# Altium schematic sheet [03] - 28PINS SCHEMATIC.SchDoc("[03] - 28PINS SCHEMATIC")
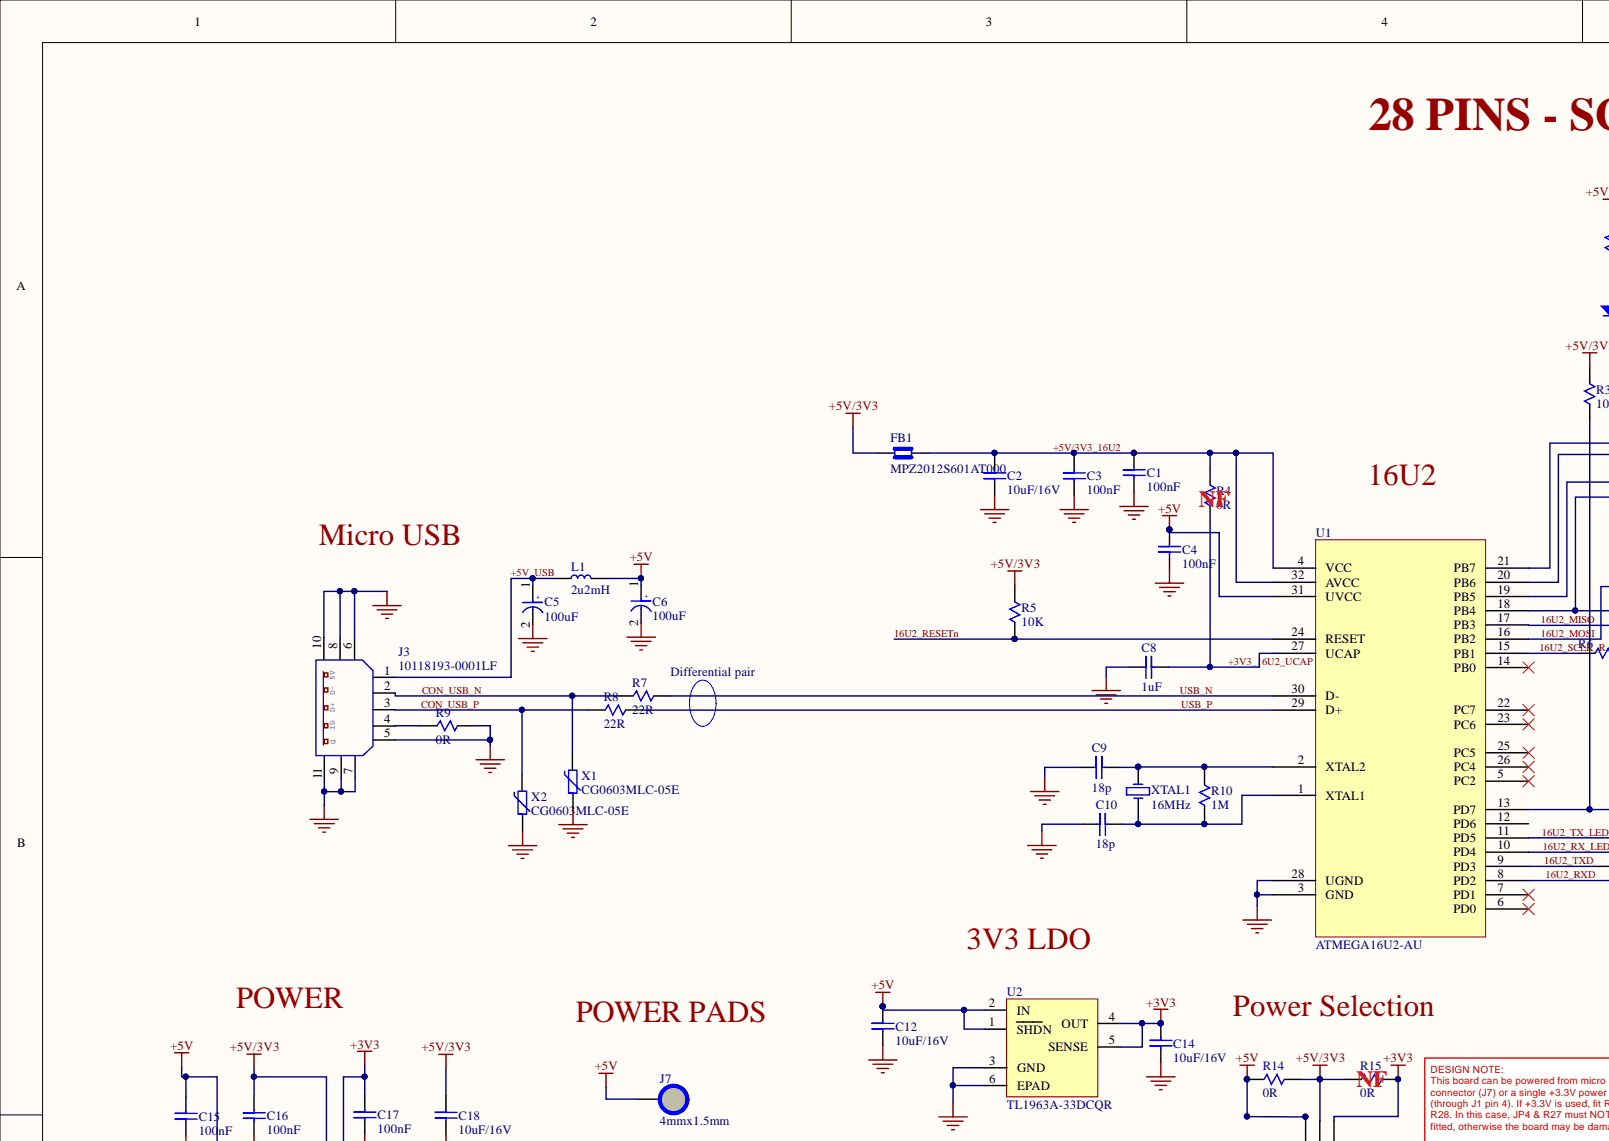
[diagram p0: [03] - 28PINS SCHEMATIC.SchDoc, top left]
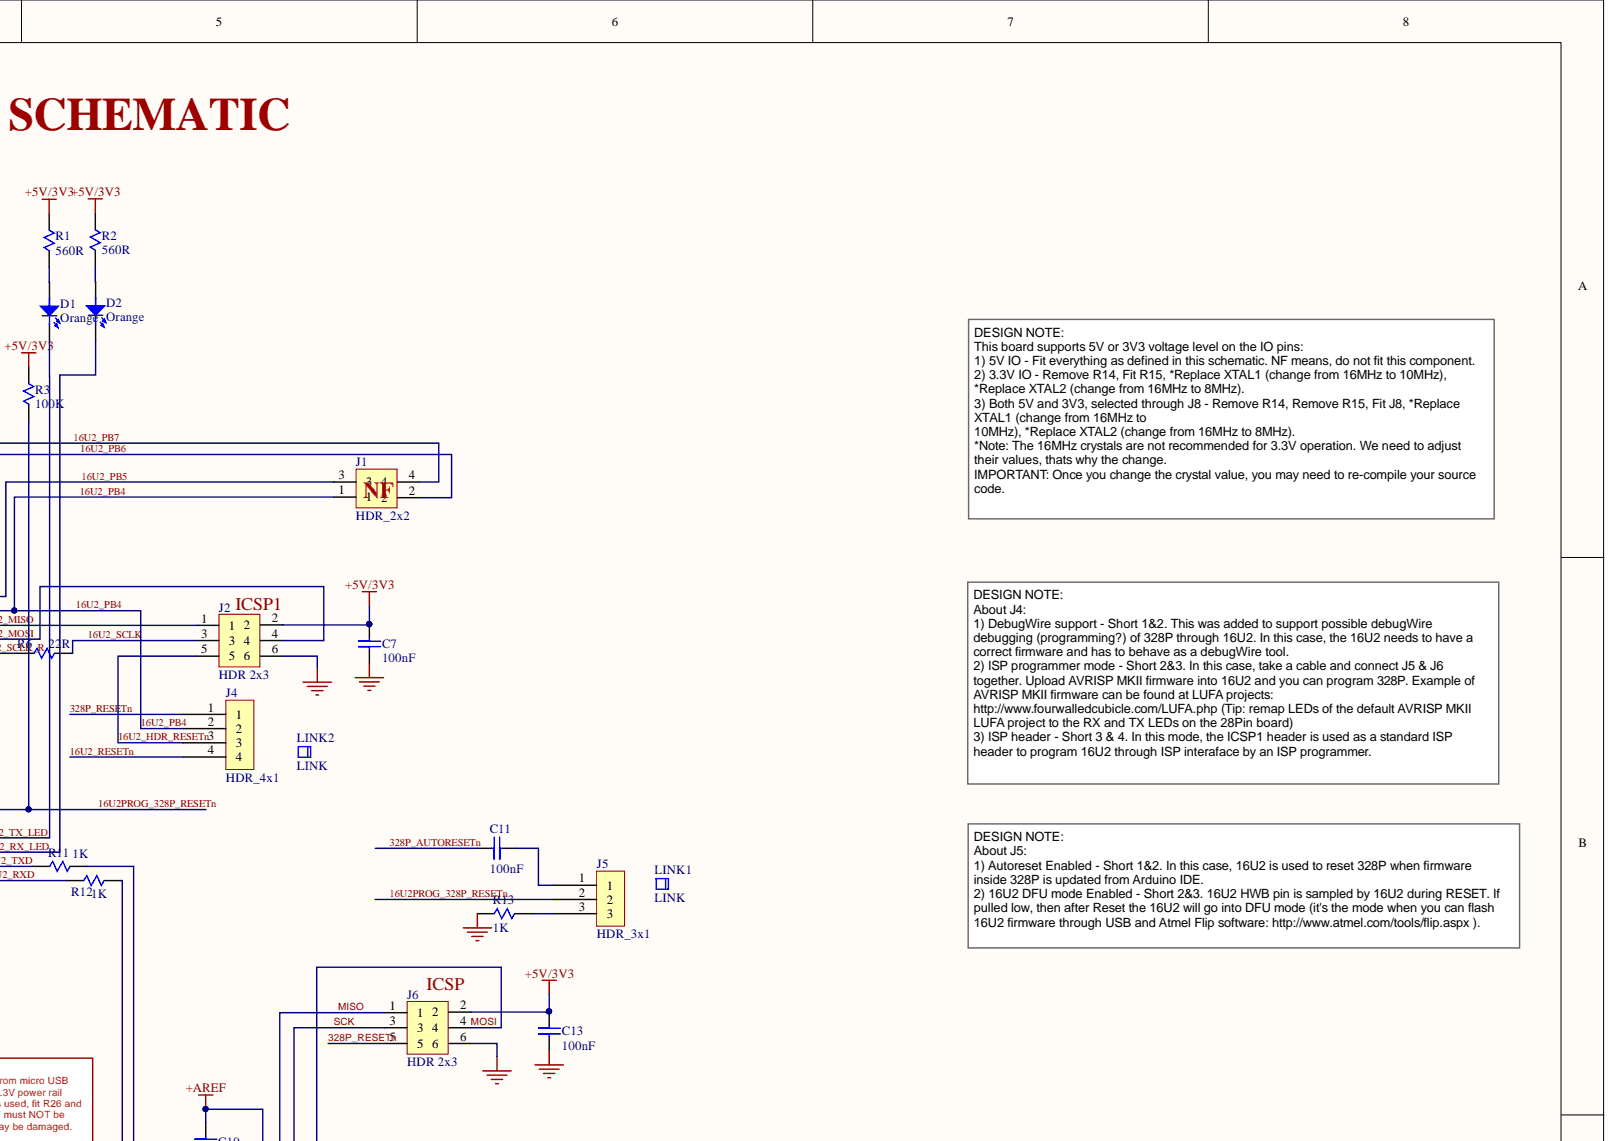
[diagram p1: [03] - 28PINS SCHEMATIC.SchDoc, top right]
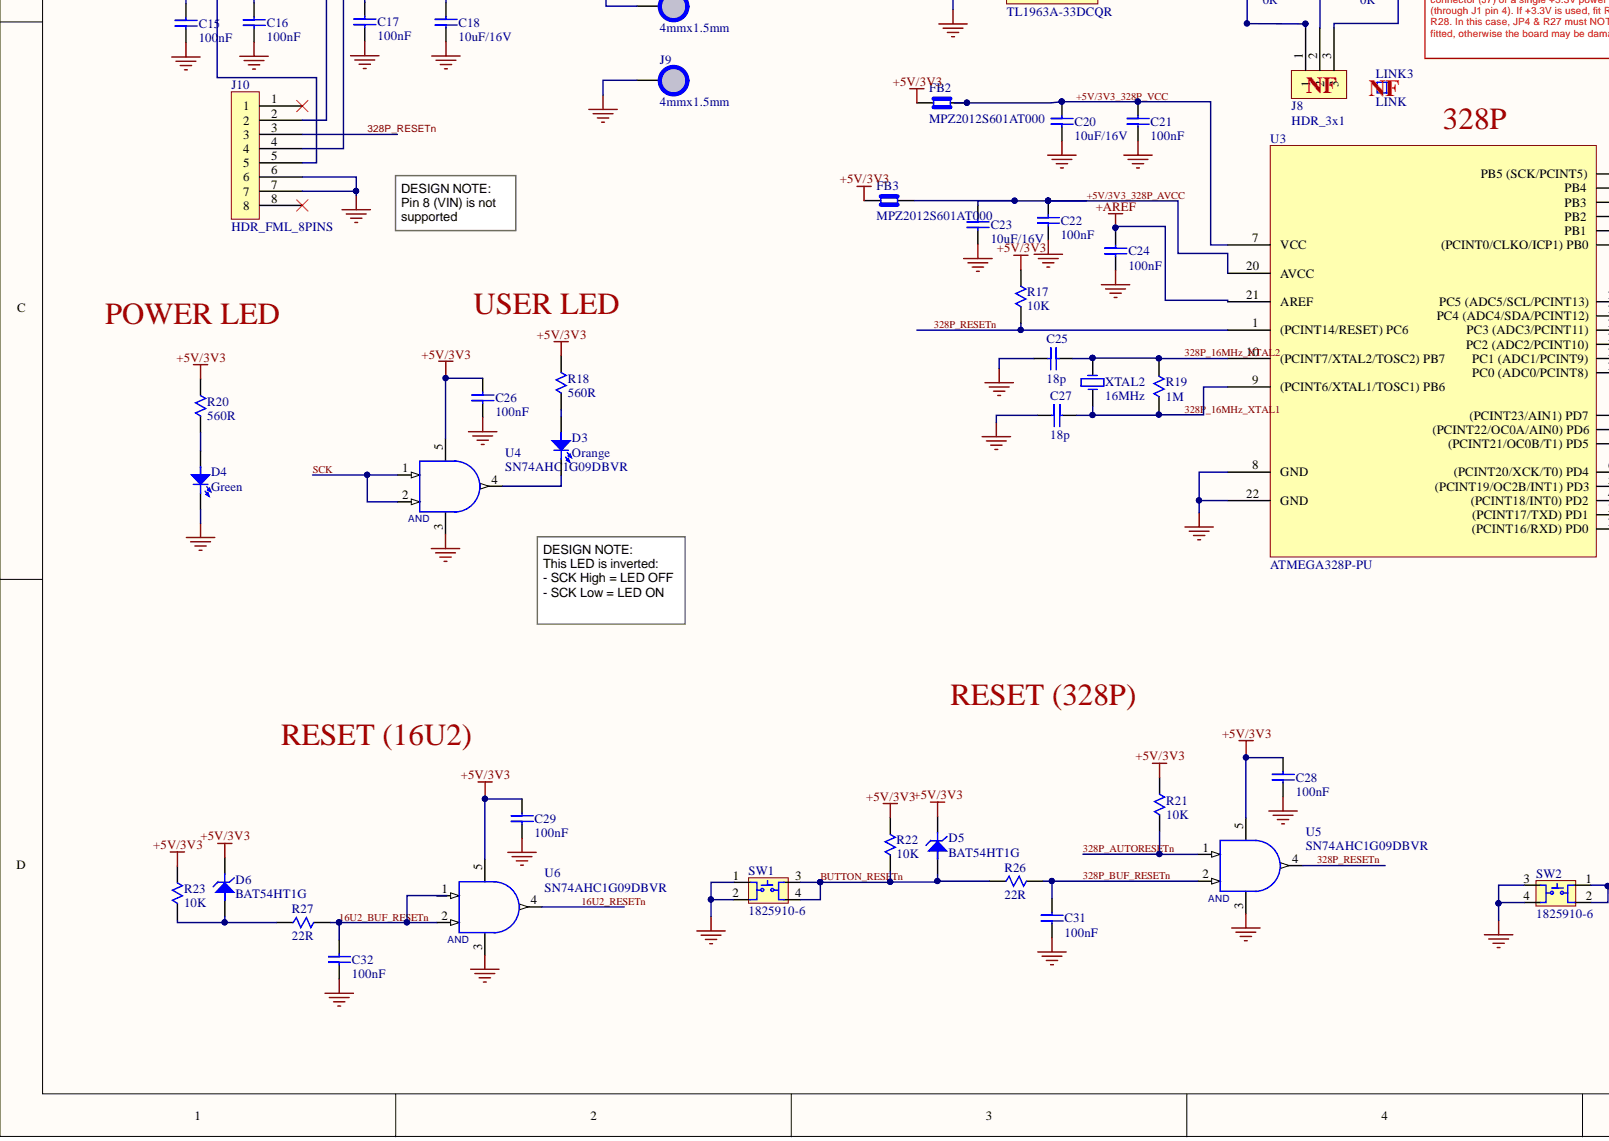
[diagram p2: [03] - 28PINS SCHEMATIC.SchDoc, bottom left]
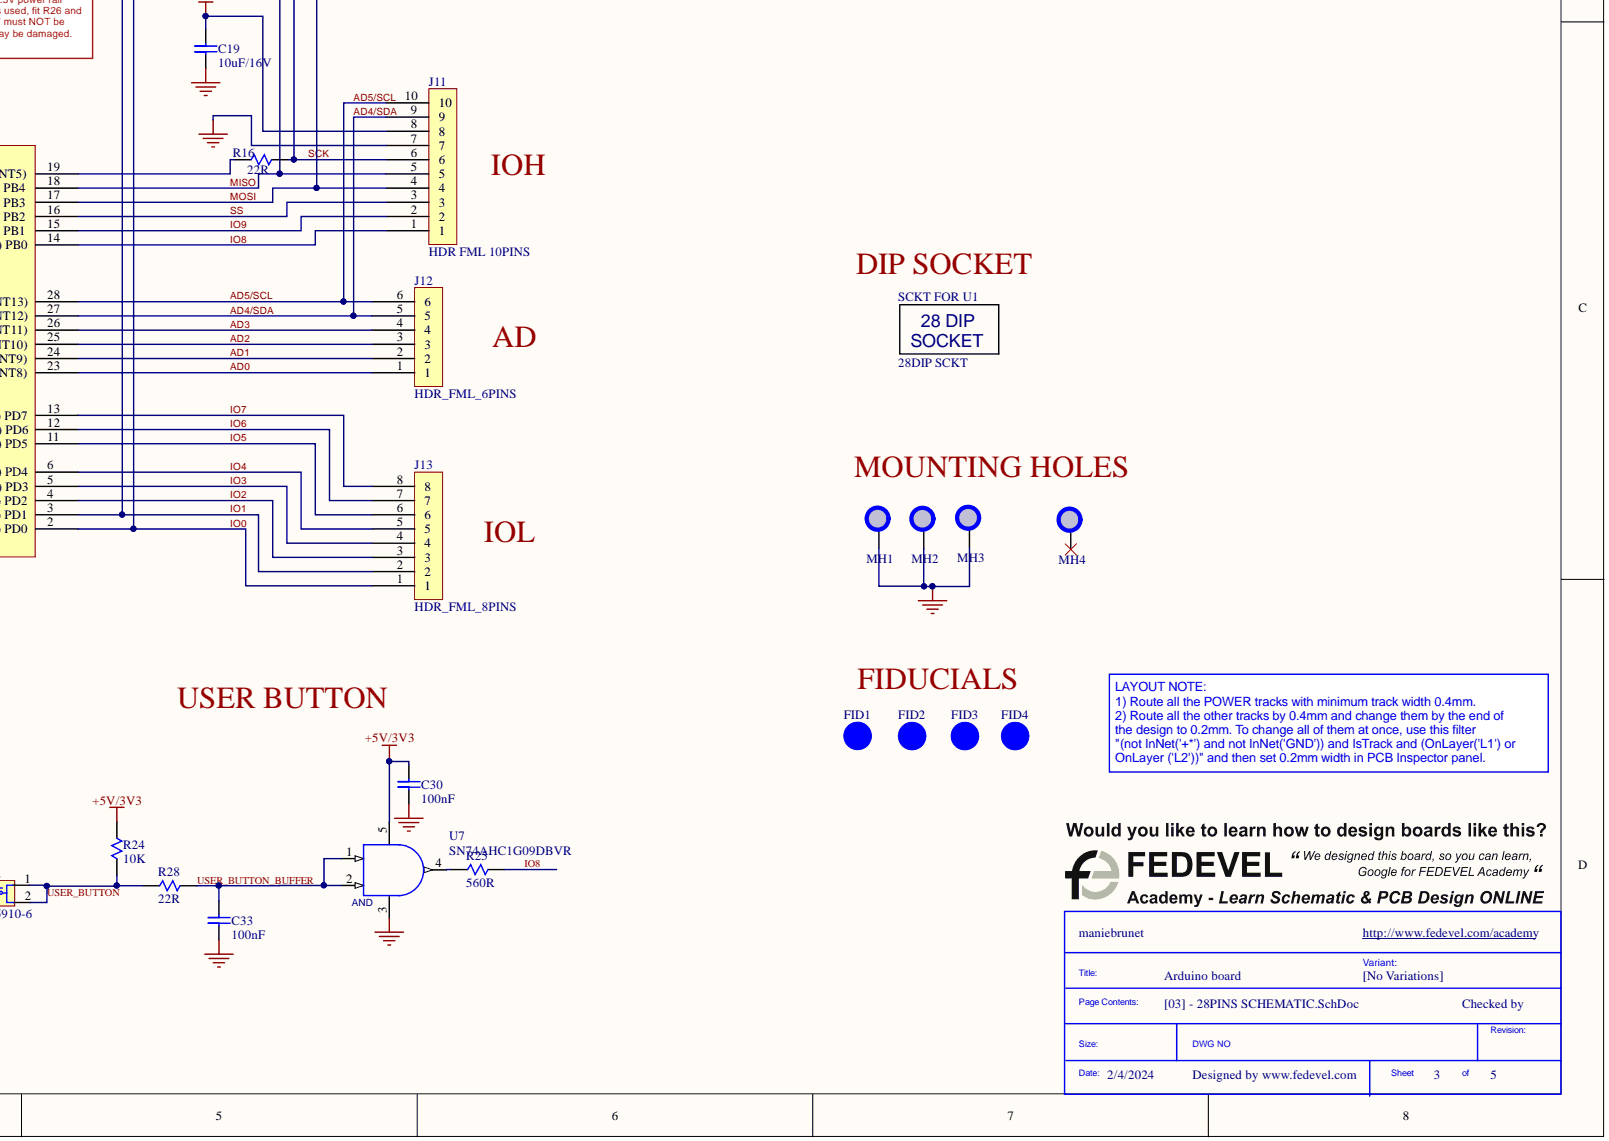
[diagram p3: [03] - 28PINS SCHEMATIC.SchDoc, bottom right]

## Parts
C1  100nF  [C0805]
C2  10uF/16V  [C0805]
C3  100nF  [C0805]
C4  100nF  [C0805]
C5  100uF  [C_ALU_C_SIZE]
C6  100uF  [C_ALU_C_SIZE]
C7  100nF  [C0805]
C8  1uF  [C0805]
C9  18p  [C0805]
C10  18p  [C0805]
C11  100nF  [C0805]
C12  10uF/16V  [C0805]
C13  100nF  [C0805]
C14  10uF/16V  [C0805]
C15  100nF  [C0805]
C16  100nF  [C0805]
C17  100nF  [C0805]
C18  10uF/16V  [C0805]
C19  10uF/16V  [C0805]
C20  10uF/16V  [C0805]
C21  100nF  [C0805]
C22  100nF  [C0805]
C23  10uF/16V  [C0805]
C24  100nF  [C0805]
C25  18p  [C0805]
C26  100nF  [C0805]
C27  18p  [C0805]
C28  100nF  [C0805]
C29  100nF  [C0805]
C30  100nF  [C0805]
C31  100nF  [C0805]
C32  100nF  [C0805]
C33  100nF  [C0805]
D1  Orange  [LED0603]
D2  Orange  [LED0603]
D3  Orange  [LED0603]
D4  Green  [LED0603]
D5  BAT54HT1G  [BAT54HT1G]
D6  BAT54HT1G  [BAT54HT1G]
FB1  MPZ2012S601AT000  [C0805]
FB2  MPZ2012S601AT000  [C0805]
FB3  MPZ2012S601AT000  [C0805]
FID1  FID_1MM  [FID_40x120D]  (value not drawn)  (no BOM)
FID2  FID_1MM  [FID_40x120D]  (value not drawn)  (no BOM)
FID3  FID_1MM  [FID_40x120D]  (value not drawn)  (no BOM)
FID4  FID_1MM  [FID_40x120D]  (value not drawn)  (no BOM)
J1  HDR_2x2  [HDR 2x2]
J2  HDR 2x3  [HDR 2x3]
J3  10118193-0001LF  [10118193]
J4  HDR_4x1  [HDR_ML_4]
J5  HDR_3x1  [HDR_ML_3]
J6  HDR 2x3  [HDR 2x3]
J7  4mmx1.5mm  [PAD_WITH_HOLE]  (no BOM)
J8  HDR_3x1  [HDR_ML_3]
J9  4mmx1.5mm  [PAD_WITH_HOLE]  (no BOM)
J10  HDR_FML_8PINS  [HDR_FML_8]
J11  HDR FML 10PINS  [HDR_FML_10]
J12  HDR_FML_6PINS  [HDR_FML_6]
J13  HDR_FML_8PINS  [HDR_FML_8]
L1  2u2mH  [R0805]
LINK1  LINK  (mechanical)
LINK2  LINK  (mechanical)
LINK3  LINK  (mechanical)
MH1  MH_7.4x3.2  [MH_7.2_3.2]  (value not drawn)  (no BOM)
MH2  MH_7.4x3.2  [MH_7.2_3.2]  (value not drawn)  (no BOM)
MH3  MH_7.4x3.2  [MH_7.2_3.2]  (value not drawn)  (no BOM)
MH4  MHNP_7.4x3.2  [MHNP_7.2_3.2]  (value not drawn)  (no BOM)
R1  560R  [R0805]
R2  560R  [R0805]
R3  100K  [R0805]
R4  0R  [R0805]
R5  10K  [R0805]
R6  22R  [R0805]
R7  22R  [R0805]
R8  22R  [R0805]
R9  0R  [R0805]
R10  1M  [R0805]
R11  1K  [R0805]
R12  1K  [R0805]
R13  1K  [R0805]
R14  0R  [R0805]
R15  0R  [R0805]
R16  22R  [R0805]
R17  10K  [R0805]
R18  560R  [R0805]
R19  1M  [R0805]
R20  560R  [R0805]
R21  10K  [R0805]
R22  10K  [R0805]
R23  10K  [R0805]
R24  10K  [R0805]
R25  560R  [R0805]
R26  22R  [R0805]
R27  22R  [R0805]
R28  22R  [R0805]
SCKT_FOR_U1  28DIP SCKT  Connector Type: DIP  [28DIP_SKT]
SW1  1825910-6  [1825910-6]
SW2  1825910-6  [1825910-6]
U1  ATMEGA16U2-AU  [TQFP32]
U2  TL1963A-33DCQR  [DCQ]
U3  ATMEGA328P-PU  [28PIN_DIP]
U4  SN74AHC1G09DBVR  [DVB_SOT_23-5]
U5  SN74AHC1G09DBVR  [DVB_SOT_23-5]
U6  SN74AHC1G09DBVR  [DVB_SOT_23-5]
U7  SN74AHC1G09DBVR  [DVB_SOT_23-5]
X1  CG0603MLC-05E  [R0603]
X2  CG0603MLC-05E  [R0603]
XTAL1  8MHz  [ABM7]  (value not drawn)
XTAL1  16MHz  [ABM7]
XTAL2  10MHz  [ABM7]  (value not drawn)
XTAL2  16MHz  [ABM7]

## Nets
+3V3: C14.2 C17.2 J8.3 J10.4 R15.2 U2.4(OUT) U2.5(SENSE)
+5V: C4.2 C6.1 C12.2 C15.2 J7.1 J8.1 J10.5 L1.2 R14.1 U1.31(UVCC) U2.1(SHDN) U2.2(IN)
+5V/3V3: C7.2 C13.2 C16.2 C18.2 C26.2 C28.2 C29.2 C30.2 D5.1 D6.1 FB1.1 FB2.1 FB3.1 J2.2 J6.2 J8.2 J10.2 R1.2 R2.2 R3.2 R5.2 R14.2 R15.1 R17.2 R18.2 R20.2 R21.2 R22.2 R23.2 R24.2 U4.5 U5.5 U6.5 U7.5
+AREF: C19.2 C24.2 J11.8 U3.21(AREF)
GND: C1.1 C2.1 C3.1 C4.1 C5.2 C6.2 C7.1 C8.1 C9.1 C10.1 C12.1 C13.1 C14.1 C15.1 C16.1 C17.1 C18.1 C19.1 C20.1 C21.1 C22.1 C23.1 C24.1 C25.1 C26.1 C27.1 C28.1 C29.1 C30.1 C31.1 C32.1 C33.1 D4.1 J2.6 J3.5 J3.6 J3.7 J3.8 J3.9 J3.10 J3.11 J6.6 J9.1 J10.6 J10.7 J11.7 MH1.1 MH2.1 MH3.1 R9.2 R13.1 SW1.1 SW1.2 SW2.3 SW2.4 U1.3(GND) U1.28(UGND) U2.3(GND) U2.6(EPAD) U3.8(GND) U3.22(GND) U4.3 U5.3 U6.3 U7.3 X1.1 X2.1
16U2_BUF_RESETn: C32.2 R27.2 U6.1 U6.2
16U2_HDR_RESETn: J2.5 J4.3
16U2_MISO: J2.1 U1.17(PB3)
16U2_MOSI: J2.4 U1.16(PB2)
16U2_PB4: J1.1(NF) J4.2 U1.18(PB4)
16U2_PB5: J1.3 U1.19(PB5)
16U2_PB6: J1.2 U1.20(PB6)
16U2_PB7: J1.4 U1.21(PB7)
16U2_RESETn: J4.4 R5.1 U1.24(RESET) U6.4
16U2_RX_LED: D2.1 U1.10(PD4)
16U2_RXD: R12.1 U1.8(PD2)
16U2_SCLK: J2.3 R6.2
16U2_SCLK_R: R6.1 U1.15(PB1)
16U2_TX_LED: D1.1 U1.11(PD5)
16U2_TXD: R11.1 U1.9(PD3)
16U2PROG_328P_RESETn: J5.2 R3.1 U1.13(PD7)
328P_16MHz_XTAL1: C27.2 R19.1 U3.9((PCINT6/XTAL1/TOSC1)_PB6) XTAL2.1
328P_16MHz_XTAL2: C25.2 R19.2 U3.10((PCINT7/XTAL2/TOSC2)_PB7) XTAL2.2
328P_AUTORESETn: C11.2 R21.1 U5.1
328P_BUF_RESETn: C31.2 R26.2 U5.2
328P_RESETn: J4.1 J6.5 J10.3 R17.1 U3.1((PCINT14/RESET)_PC6) U5.4
+3V3_16U2_UCAP: C8.2 R4.1 U1.27(UCAP)
+5V/3V3_16U2: C1.2 C2.2 C3.2 FB1.2 R4.2 U1.4(VCC) U1.32(AVCC)
+5V/3V3_328P_AVCC: C22.2 C23.2 FB3.2 U3.20(AVCC)
+5V/3V3_328P_VCC: C20.2 C21.2 FB2.2 U3.7(VCC)
+5V_USB: C5.1 J3.1 L1.1
AD0: J12.1 U3.23(PC0_(ADC0/PCINT8))
AD1: J12.2 U3.24(PC1_(ADC1/PCINT9))
AD2: J12.3 U3.25(PC2_(ADC2/PCINT10))
AD3: J12.4 U3.26(PC3_(ADC3/PCINT11))
AD4/SDA: J11.9 J12.5 U3.27(PC4_(ADC4/SDA/PCINT12))
AD5/SCL: J11.10 J12.6 U3.28(PC5_(ADC5/SCL/PCINT13))
BUTTON_RESETn: D5.2 R22.1 R26.1 SW1.3 SW1.4
CON_USB_N: J3.2 R7.1 X1.2
CON_USB_P: J3.3 R8.1 X2.2
IO0: J13.1 R11.2 U3.2((PCINT16/RXD)_PD0)
IO1: J13.2 R12.2 U3.3((PCINT17/TXD)_PD1)
IO2: J13.3 U3.4((PCINT18/INT0)_PD2)
IO3: J13.4 U3.5((PCINT19/OC2B/INT1)_PD3)
IO4: J13.5 U3.6((PCINT20/XCK/T0)_PD4)
IO5: J13.6 U3.11((PCINT21/OC0B/T1)_PD5)
IO6: J13.7 U3.12((PCINT22/OC0A/AIN0)_PD6)
IO7: J13.8 U3.13((PCINT23/AIN1)_PD7)
IO8: J11.1 R25.2 U3.14((PCINT0/CLKO/ICP1)_PB0)
IO9: J11.2 U3.15(PB1)
MISO: J6.1 J11.5 U3.18(PB4)
MOSI: J6.4 J11.4 U3.17(PB3)
SCK: J6.3 J11.6 R16.2 U4.1 U4.2
SS: J11.3 U3.16(PB2)
USB_N: R7.2 U1.30(D-)
USB_P: R8.2 U1.29(D+)
USER_BUTTON: R24.1 R28.1 SW2.1 SW2.2
USER_BUTTON_BUFFER: C33.2 R28.2 U7.1 U7.2
NetC9_2: C9.2 R10.2 U1.2(XTAL2) XTAL1.2
NetC10_2: C10.2 R10.1 U1.1(XTAL1) XTAL1.1
NetC11_1: C11.1 J5.1
NetD1_2: D1.2 R1.1
NetD2_2: D2.2 R2.1
NetD3_1: D3.1 U4.4
NetD3_2: D3.2 R18.1
NetD4_2: D4.2 R20.1
NetD6_2: D6.2 R23.1 R27.1
NetJ3_4: J3.4 R9.1
NetJ5_3: J5.3 R13.2
NetR16_1: R16.1 U3.19(PB5_(SCK/PCINT5))
NetR25_1: R25.1 U7.4

Not connected: J10 1 8; MH4 1; U1 5(PC2) 6(PD0) 7(PD1) 12(PD6) 14(PB0) 22(PC7) 23(PC6) 25(PC5) 26(PC4)
